# Altium schematic sheet current_cntrl.SchDoc
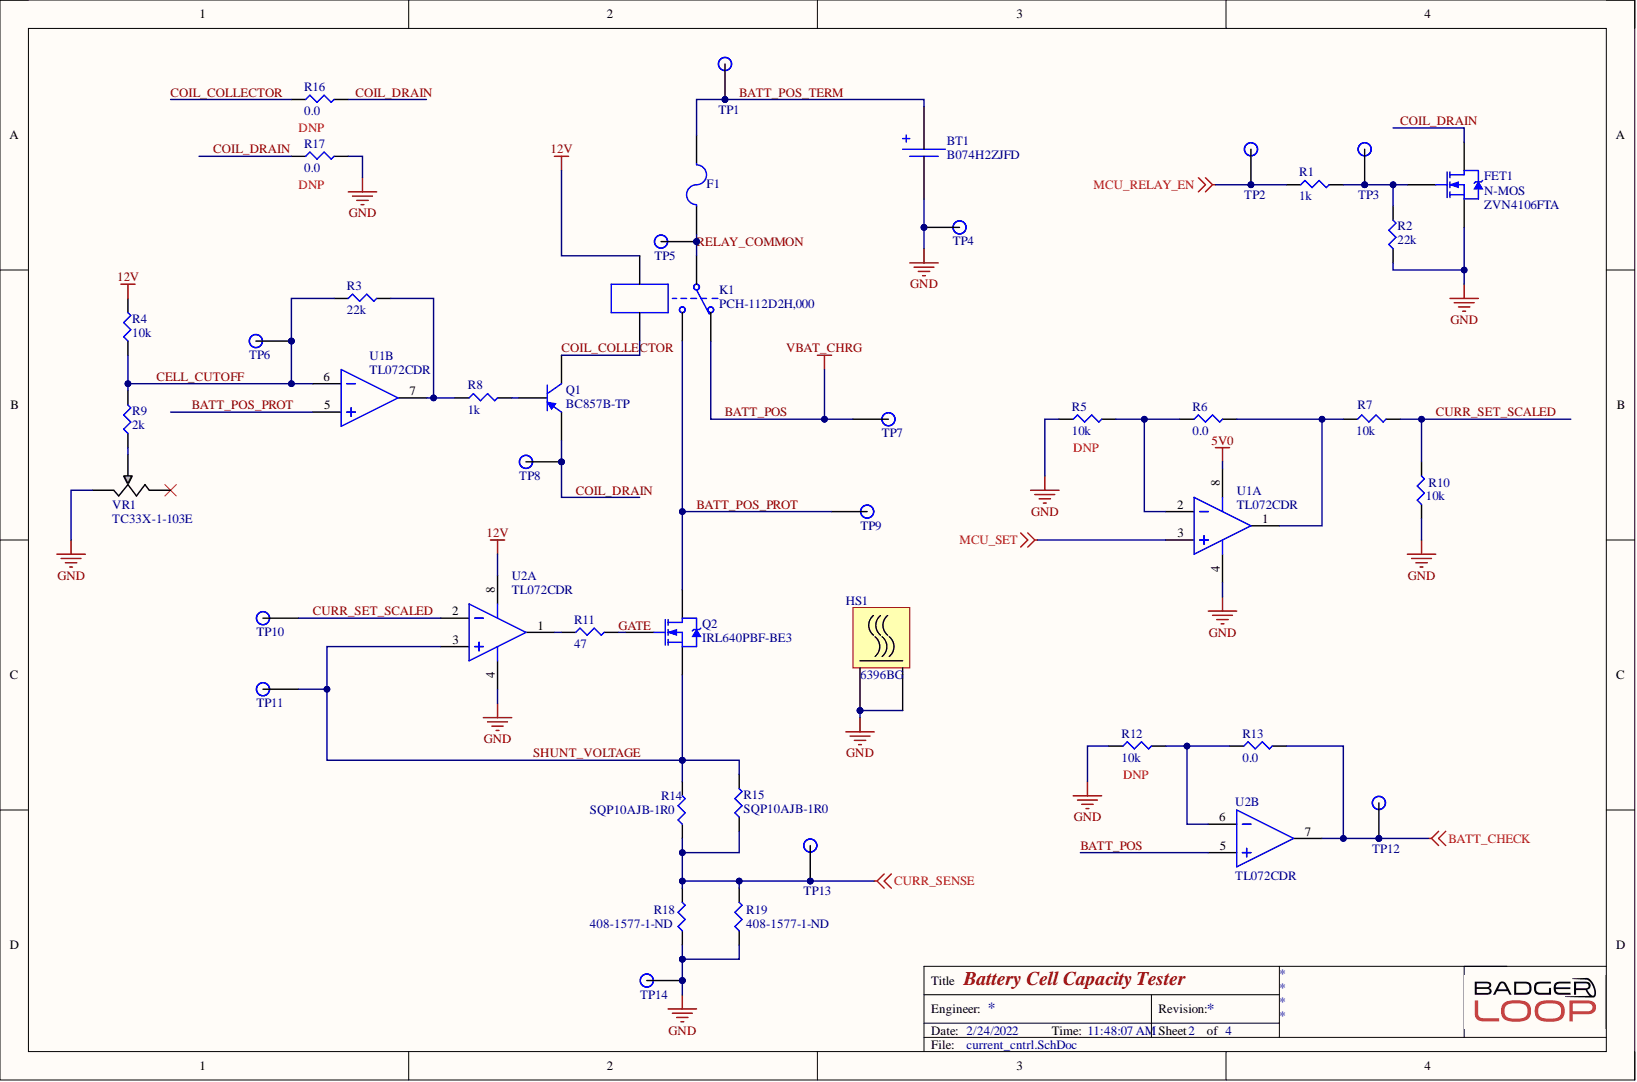
[diagram p0: current_cntrl.SchDoc]

## Parts
BT1  B074H2ZJFD  [B074H2ZJFD]
F1  3568  [Fuse Holder 30A 500V 1 Circuit Blade Through Hole]  (value not drawn)
FET1  N-MOS  Manufacturer P/N: ZVN4106FTA  [SOT95P240X110-3N]
HS1  6396BG  [6396BG]
K1  PCH-112D2H,000  [PCH-112D2H,000]
Q1  BC857B-TP  [SOT95P237X120-3N]
Q2  IRL640PBF-BE3  [TO254P470X990X1910-3P]
R1  1k  [SMD-0805-RES]
R2  22k  [SMD-0805-RES]
R3  22k  [SMD-0805-RES]
R4  10k  [SMD-0805-RES]
R5  10k  [SMD-0805-RES]
R6  0.0  [SMD-0805-RES]
R7  10k  [SMD-0805-RES]
R8  1k  [SMD-0805-RES]
R9  2k  [SMD-0805-RES]
R10  10k  [SMD-0805-RES]
R11  47  [SMD-0805-RES]
R12  10k  [SMD-0805-RES]
R13  0.0  [SMD-0805-RES]
R14  SQP10AJB-1R0  [SQP10A]
R15  SQP10AJB-1R0  [SQP10A]
R16  0.0  [SMD-0805-RES]
R17  0.0  [SMD-0805-RES]
R18  Supplier Part Number 1: 408-1577-1-ND  [SMD-1206-RES]  (hidden comment: KRL1632E-M-R039-F-T5)
R19  Supplier Part Number 1: 408-1577-1-ND  [SMD-1206-RES]  (hidden comment: KRL1632E-M-R039-F-T5)
TP1  TP  [Test Point]
TP2  TP  [Test Point]
TP3  TP  [Test Point]
TP4  TP  [Test Point]
TP5  TP  [Test Point]
TP6  TP  [Test Point]
TP7  TP  [Test Point]
TP8  TP  [Test Point]
TP9  TP  [Test Point]
TP10  TP  [Test Point]
TP11  TP  [Test Point]
TP12  TP  [Test Point]
TP13  TP  [Test Point]
TP14  TP  [Test Point]
U1  TL072CDR  [SOP127P600X175-8N]  (parts A,B)
U2  TL072CDR  [SOP127P600X175-8N]  (parts A,B)
VR1  TC33X-1-103E  [TC33X-1-103E]

## Nets
5V0: U1.8
12V: K1.1 R4.2 U2.8
BATT_CHECK: R13.2 TP12.1 U2.7
CURR_SENSE: R14.1 R15.1 R18.2 R19.2 TP13.1
GND: BT1.2 FET1.3 HS1.0 HS1.1 R2.1 R5.1 R10.1 R12.1 R17.2 R18.1 R19.1 TP4.1 TP14.1 U1.4 U2.4 VR1.1
MCU_RELAY_EN: R1.1 TP2.1
MCU_SET: U1.3
BATT_POS: K1.4 TP7.1 U2.5
BATT_POS_PROT: K1.5 Q2.2 TP9.1 U1.5
BATT_POS_TERM: BT1.1 F1.2 TP1.1
CELL_CUTOFF: R3.1 R4.1 R9.2 TP6.1 U1.6
COIL_COLLECTOR: K1.2 Q1.1 R16.1
COIL_DRAIN: FET1.2 Q1.3 R16.2 R17.1 TP8.1
CURR_SET_SCALED: R7.2 R10.2 TP10.1 U2.2
GATE: Q2.1 R11.2
RELAY_COMMON: F1.1 K1.3 TP5.1
SHUNT_VOLTAGE: Q2.3 R14.2 R15.2 TP11.1 U2.3
NetFET1_1: FET1.1 R1.2 R2.2 TP3.1
NetQ1_2: Q1.2 R8.2
NetR3_2: R3.2 R8.1 U1.7
NetR5_2: R5.2 R6.1 U1.2
NetR6_2: R6.2 R7.1 U1.1
NetR9_1: R9.1 VR1.3
NetR11_1: R11.1 U2.1
NetR12_2: R12.2 R13.1 U2.6

Not connected: VR1 2

## Library data of the parts
BT1 = B074H2ZJFD: BCP 26650 Battery Holder Case Storage Box; Number of Cells: 1; Component Kind: Standard; ComponentLink1Description: https://www.amazon.com/BCP-Plastic-Single-Battery-Storage/dp/B074H2ZJFD; ComponentLink1URL: https://www.amazon.com/BCP-Plastic-Single-Battery-Storage/dp/B074H2ZJFD; Library Name: misc.SchLib; Manufacturer: BCP; Mount: Through Hole; Pricing 1: 5=13.59(USD); Supplier 1: Amazon
F1 = 3568: FUSE HOLDER MINI AUTO PC; Manufacturer: Keystone Electronics; Approvals: UL; Body Material: Nylon; Color: Black; Current Rating: 20A; Fuse Type: Automotive; Illumination Type: Illuminated; Material: Brass,Tin,Nylon; Number of Circuits: 1; Voltage: 500V; Component Kind: Standard; ComponentLink1Description: http://www.keyelco.com/userAssets/file/M60-2p40.pdf?src-supplier=Future+Electronics; ComponentLink1URL: http://www.keyelco.com/userAssets/file/M60-2p40.pdf?src-supplier=Future+Electronics; ComponentLink2Description: https://www.alliedelec.com/m/d/15b4f09b8ba48c4b08efedd730709c21.pdf?src-supplier=Allied+Electronics; ComponentLink2URL: https://www.alliedelec.com/m/d/15b4f09b8ba48c4b08efedd730709c21.pdf?src-supplier=Allied+Electronics; Contact Material: Brass,Tin; Contact Plating: Tin; Depth: 6.73mm; Flammability Rating: UL94 V-0; Fuse Current: 20A; Lead Free: Lead Free; Length: 16mm; Library Name: misc.SchLib; Manufacturer Part Number: 3568; Max Operating Temperature: 145°C; Min Operating Temperature: -50°C; Mount: PCB,Vertical; Number of Poles: 1; Orientation: Vertical; Package Quantity: 1; REACH SVHC: No SVHC; Stock 1: 0; Supplier 1: Digi-Key; Supplier Part Number 1: 3568K-ND; Termination: PC Pin; Voltage Rating (AC): 500V
FET1 = N-MOS Enhancement Mode: MOSFET N-CH 60V 200MA SOT23-3; Manufacturer: Zetex; Component Kind: Standard; Digikey P/N: ZVN4106FCT-ND; Library Name: misc.SchLib
HS1 = 6396BG: TO-220/218 Packages 5.60 C/W Thermal Resistance Extruded Heat Sink; Manufacturer: Aavid Thermalloy; Finish: Anodized; Natural Thermal Resistance: 5.6°C/W; Shape: Fins,Rectangular; Thermal Resistance @ Forced Air Flow (100 LFM): 1.5°C/W; Case/Package: Radial; Category: Heat Sinks; Color: Black; Component Kind: Standard; ComponentLink1Description: https://datasheet.ciiva.com/15226/getdatasheetpartid-419829-15226549.pdf?src-supplier=Verical; ComponentLink1URL: https://datasheet.ciiva.com/15226/getdatasheetpartid-419829-15226549.pdf?src-supplier=Verical; ComponentLink2Description: https://datasheet.ciiva.com/1005/aavid-board-level-heatsinks-catalog-1005003.pdf?src-supplier=Digi-Key; ComponentLink2URL: https://datasheet.ciiva.com/1005/aavid-board-level-heatsinks-catalog-1005003.pdf?src-supplier=Digi-Key; Depth: 25.4mm; Height: 25.4mm; Height Off Base: 1inch; Lead Free: Lead Free; Length: 41.91mm; Library Name: misc.SchLib; Manufacturer Part Number: 6396BG; Material: Aluminium; Mount: Bolt,PC Pin,Screw; Number of Pins: 220; Package Quantity: 500; Pricing 1: 1=1.68000, 10=1.59700, 25=1.55440, 50=1.51260, 100=1.42850, 250=1.34452, 500=1.26048, 1000=1.17645, 5000=1.13443 (USD); Radiation Hardening: No; REACH SVHC: No SVHC; RoHS: Yes; RoHS Compliant: Yes; Stock 1: 13758; Supplier 1: Digi-Key; Supplier Part Number 1: 6396BG-ND; Type: Passive; Width: 41.91mm
K1 = PCH-112D2H,000: RELAY GEN PURPOSE SPDT 5A 12V; Manufacturer: TE Connectivity; Contact Form: SPDT (1 Form C); Component Kind: Standard; ComponentLink1Description: https://www.te.com/commerce/DocumentDelivery/DDEController?Action=srchrtrv&DocNm=PCH_series_relay_data_sheet_E&DocType=DS&DocLang=English; ComponentLink1URL: https://www.te.com/commerce/DocumentDelivery/DDEController?Action=srchrtrv&DocNm=PCH_series_relay_data_sheet_E&DocType=DS&DocLang=English; Digikey Link: https://www.digikey.com/en/products/detail/te-connectivity-potter-brumfield-relays/PCH-112D2H-000/1095216; Digikey P/N: PB891-ND; Library Name: misc.SchLib; Manufacturer P/N: PCH-112D2H,000
Q1 = BC857B-TP: Bipolar (BJT) Transistor PNP 45 V 100 mA 200MHz 310 mW Surface Mount SOT-23; Manufacturer: Micro Commercial Co; Component Kind: Standard; Library Name: ic.SchLib; Manufacturer Part Number: BC857B-TP; Supplier 1: Digi-Key; Supplier Part Number 1: BC857B-TPMSCT-ND
Q2 = IRL640PBF-BE3: MOSFET N-CH 200V 17A TO220AB; Manufacturer: Vishay Siliconix; Component Kind: Standard; ComponentLink1Description: https://www.digikey.com/en/products/detail/vishay-siliconix/IRL640PBF-BE3/12396452; ComponentLink1URL: https://www.digikey.com/en/products/detail/vishay-siliconix/IRL640PBF-BE3/12396452; ComponentLink2Description: https://www.mouser.com/datasheet/2/427/irl640-1768894.pdf; ComponentLink2URL: https://www.mouser.com/datasheet/2/427/irl640-1768894.pdf; ComponentLink3Description: https://www.mouser.com/ProductDetail/Vishay-Siliconix/IRL640PBF-BE3?qs=7MVldsJ5UaxejT%252BVi%2FA9OA%3D%3D; ComponentLink3URL: https://www.mouser.com/ProductDetail/Vishay-Siliconix/IRL640PBF-BE3?qs=7MVldsJ5UaxejT%252BVi%2FA9OA%3D%3D; Library Name: misc.SchLib; Supplier: Digi-Key; Supplier 1: Future Electronics; Supplier P/N: 742-IRL640PBF-BE3-ND
R1 R8 = ERJ-P06J102V: RES SMD 1K OHM 5% 1/2W 0805; Manufacturer: Panasonic Electronic Components; @ qty: 1500; Factory Stock: 0; Minimum Quantity: 1; Power (Watts): 0.5W, 1/2W; Temperature Coefficient: ±200ppm/°C; Unit Price (USD): 0.01839; Component Kind: Standard; Composition: Thick Film; Datasheets: https://industrial.panasonic.com/cdbs/www-data/pdf/RDA0000/AOA0000C243.pdf; Digi-Key Part Number: P1.0KADCT-ND; Failure Rate: -; Features: Automotive AEC-Q200, Pulse Withstanding; Height - Seated (Max): 0.028" (0.70mm); Image: //media.digikey.com/Renders/Panasonic%20Renders/ERJ-0805%20Pkg.jpg; Library Name: resistors.SchLib; Number of Terminations: 2; Operating Temperature: -55°C ~ 155°C; Package / Case: 0805 (2012 Metric); Packaging: Cut Tape (CT); Part Number: ERJ-P06J102V; Part Status: Active; Quantity Available: 124757; Series: ERJ; Size / Dimension: 0.079" L x 0.049" W (2.00mm x 1.25mm); Supplier Device Package: 0805; Tolerance: ±5%; Value: 1k
R2 R3 = ERJ-P06J223V: RES SMD 22K OHM 5% 1/2W 0805; Manufacturer: Panasonic Electronic Components; @ qty: 1500; Factory Stock: 0; Minimum Quantity: 1; Power (Watts): 0.5W, 1/2W; Temperature Coefficient: ±200ppm/°C; Unit Price (USD): 0.01839; Component Kind: Standard; Composition: Thick Film; Datasheets: https://industrial.panasonic.com/cdbs/www-data/pdf/RDA0000/AOA0000C243.pdf; Digi-Key Part Number: P22KADCT-ND; Failure Rate: -; Features: Automotive AEC-Q200, Pulse Withstanding; Height - Seated (Max): 0.028" (0.70mm); Image: //media.digikey.com/Renders/Panasonic%20Renders/ERJ-0805%20Pkg.jpg; Library Name: resistors.SchLib; Number of Terminations: 2; Operating Temperature: -55°C ~ 155°C; Package / Case: 0805 (2012 Metric); Packaging: Cut Tape (CT); Part Number: ERJ-P06J223V; Part Status: Active; Quantity Available: 10848; Series: ERJ; Size / Dimension: 0.079" L x 0.049" W (2.00mm x 1.25mm); Supplier Device Package: 0805; Tolerance: ±5%; Value: 22k
R4 = ERJ-P06J103V: RES SMD 10K OHM 5% 1/2W 0805; Manufacturer: Panasonic Electronic Components; @ qty: 1500; Factory Stock: 0; Minimum Quantity: 1; Power (Watts): 0.5W, 1/2W; Temperature Coefficient: ±200ppm/°C; Unit Price (USD): 0.01839; Component Kind: Standard; Composition: Thick Film; Datasheets: https://industrial.panasonic.com/cdbs/www-data/pdf/RDA0000/AOA0000C243.pdf; Digi-Key Part Number: P10KADCT-ND; Failure Rate: -; Features: Automotive AEC-Q200, Pulse Withstanding; Height - Seated (Max): 0.028" (0.70mm); Image: //media.digikey.com/Renders/Panasonic%20Renders/ERJ-0805%20Pkg.jpg; Library Name: resistors.SchLib; Number of Terminations: 2; Operating Temperature: -55°C ~ 155°C; Package / Case: 0805 (2012 Metric); Packaging: Cut Tape (CT); Part Number: ERJ-P06J103V; Part Status: Active; Quantity Available: 413576; Series: ERJ; Size / Dimension: 0.079" L x 0.049" W (2.00mm x 1.25mm); Supplier Device Package: 0805; Tolerance: ±5%; Value: 10k
R5 R12 = ERJ-6ENF1002V: RES SMD 10K OHM 1% 1/8W 0805; Manufacturer: Panasonic Electronic Components; @ qty: 1500; Factory Stock: 0; Minimum Quantity: 1; Power (Watts): 0.125W, 1/8W; Temperature Coefficient: ±100ppm/°C; Unit Price (USD): 0.00729; Component Kind: Standard; Composition: Thick Film; Datasheets: http://industrial.panasonic.com/www-cgi/jvcr13pz.cgi?E+PZ+3+AOA0002+ERJ6ENF1002V+7+WW; Digi-Key Part Number: P10.0KCCT-ND; DNP: DNP; Failure Rate: -; Features: Automotive AEC-Q200; Height - Seated (Max): 0.028" (0.70mm); Image: //media.digikey.com/Renders/Panasonic%20Renders/ERJ-0805%20Pkg.jpg; Library Name: resistors.SchLib; Number of Terminations: 2; Operating Temperature: -55°C ~ 155°C; Package / Case: 0805 (2012 Metric); Packaging: Cut Tape (CT); Part Number: ERJ-6ENF1002V; Part Status: Active; Quantity Available: 3985614; Series: ERJ; Size / Dimension: 0.079" L x 0.049" W (2.00mm x 1.25mm); Supplier Device Package: 0805; Tolerance: ±1%; Value: 10k
R6 R13 R16 R17 = ERJ-6GEY0R00V: RES SMD 0.0 OHM JUMPER 1/8W 0805; Manufacturer: Panasonic Electronic Components; @ qty: 1500; Factory Stock: 0; Minimum Quantity: 1; Power (Watts): 0.125W, 1/8W; Unit Price (USD): 0.00544; Component Kind: Standard; Composition: Thick Film; Datasheets: http://industrial.panasonic.com/www-cgi/jvcr13pz.cgi?E+PZ+3+AOA0001+ERJ6GEY0R00V+7+WW; Digi-Key Part Number: P0.0ACT-ND; Failure Rate: -; Features: Automotive AEC-Q200; Height - Seated (Max): 0.028" (0.70mm); Image: //media.digikey.com/Renders/Panasonic%20Renders/ERJ-0805%20Pkg.jpg; Library Name: resistors.SchLib; Number of Terminations: 2; Operating Temperature: -55°C ~ 155°C; Package / Case: 0805 (2012 Metric); Packaging: Cut Tape (CT); Part Number: ERJ-6GEY0R00V; Part Status: Active; Quantity Available: 1597627; Series: ERJ; Size / Dimension: 0.079" L x 0.049" W (2.00mm x 1.25mm); Supplier Device Package: 0805; Temperature Coefficient: -; Tolerance: Jumper; Value: 0.0
  R16 R17: DNP: DNP
R7 R10 = ERJ-6GEYJ103V: RES SMD 10K OHM 5% 1/8W 0805; Manufacturer: Panasonic Electronic Components; @ qty: 1500; Factory Stock: 0; Minimum Quantity: 1; Power (Watts): 0.125W, 1/8W; Temperature Coefficient: ±200ppm/°C; Unit Price (USD): 0.00496; Component Kind: Standard; Composition: Thick Film; Datasheets: http://industrial.panasonic.com/www-cgi/jvcr13pz.cgi?E+PZ+3+AOA0001+ERJ6GEYJ103V+7+WW; Digi-Key Part Number: P10KACT-ND; Failure Rate: -; Features: Automotive AEC-Q200; Height - Seated (Max): 0.028" (0.70mm); Image: //media.digikey.com/Renders/Panasonic%20Renders/ERJ-0805%20Pkg.jpg; Library Name: resistors.SchLib; Number of Terminations: 2; Operating Temperature: -55°C ~ 155°C; Package / Case: 0805 (2012 Metric); Packaging: Cut Tape (CT); Part Number: ERJ-6GEYJ103V; Part Status: Active; Quantity Available: 2328224; Series: ERJ; Size / Dimension: 0.079" L x 0.049" W (2.00mm x 1.25mm); Supplier Device Package: 0805; Tolerance: ±5%; Value: 10k
R9 = ERJ-P06F2001V: RES SMD 2K OHM 1% 1/2W 0805; Manufacturer: Panasonic Electronic Components; @ qty: 1500; Factory Stock: 0; Minimum Quantity: 1; Power (Watts): 0.5W, 1/2W; Temperature Coefficient: ±100ppm/°C; Unit Price (USD): 0.02534; Component Kind: Standard; Composition: Thick Film; Datasheets: https://industrial.panasonic.com/cdbs/www-data/pdf/RDA0000/AOA0000C243.pdf; Digi-Key Part Number: P16065CT-ND; Failure Rate: -; Features: Automotive AEC-Q200, Pulse Withstanding; Height - Seated (Max): 0.028" (0.70mm); Image: //media.digikey.com/Renders/Panasonic%20Renders/ERJ-0805%20Pkg.jpg; Library Name: resistors.SchLib; Number of Terminations: 2; Operating Temperature: -55°C ~ 155°C; Package / Case: 0805 (2012 Metric); Packaging: Cut Tape (CT); Part Number: ERJ-P06F2001V; Part Status: Active; Quantity Available: 19425; Series: ERJ; Size / Dimension: 0.079" L x 0.049" W (2.00mm x 1.25mm); Supplier Device Package: 0805; Tolerance: ±1%; Value: 2k
R11 = ERJ-P6WF47R0V: RES SMD 47 OHM 1% 1/2W 0805; Manufacturer: Panasonic Electronic Components; @ qty: 1500; Factory Stock: 0; Minimum Quantity: 1; Power (Watts): 0.5W, 1/2W; Temperature Coefficient: ±200ppm/°C; Unit Price (USD): 0.10507; Component Kind: Standard; Composition: Thick Film; Datasheets: https://industrial.panasonic.com/cdbs/www-data/pdf/RDA0000/AOA0000C243.pdf; Digi-Key Part Number: P16869CT-ND; Failure Rate: -; Features: Automotive AEC-Q200, Pulse Withstanding; Height - Seated (Max): 0.030" (0.75mm); Image: //media.digikey.com/Renders/Panasonic%20Renders/ERJ-0805%20Pkg.jpg; Library Name: resistors.SchLib; Number of Terminations: 2; Operating Temperature: -55°C ~ 155°C; Package / Case: 0805 (2012 Metric); Packaging: Cut Tape (CT); Part Number: ERJ-P6WF47R0V; Part Status: Active; Quantity Available: 3398; Series: ERJ; Size / Dimension: 0.079" L x 0.049" W (2.00mm x 1.25mm); Supplier Device Package: 0805; Tolerance: ±1%; Value: 47
R14 R15 = SQP10AJB-1R0: RES 1 OHM 5% 10W AXIAL; Manufacturer: YAGEO; Component Kind: Standard; ComponentLink1Description: https://www.yageo.com/upload/media/product/productsearch/datasheet/lr/YAGEO%20SQP_NSP_datasheet_2021v0.pdf; ComponentLink1URL: https://www.yageo.com/upload/media/product/productsearch/datasheet/lr/YAGEO%20SQP_NSP_datasheet_2021v0.pdf; Digikey Link: https://www.digikey.com/en/products/detail/yageo/SQP10AJB-1R0/18711; Library Name: resistors.SchLib; Manufacturer Part Number: SQP10AJB-1R0; Pricing 1: 1=0.81,10=7.00 (USD); Supplier 1: Digi-Key; Supplier Part Number 1: 1.0W-10-ND
R18 R19 = KRL1632E-M-R039-F-T5: 39 mOhms ±1% 0.75W, 3/4W Chip Resistor 1206 (3216 Metric) Automotive AEC-Q200, Current Sense Metal Foil; Resistance: 0.039; Component Kind: Standard; ComponentLink1Description: https://www.digikey.com/en/products/detail/susumu/KRL1632E-M-R039-F-T5/3738003; ComponentLink1URL: https://www.digikey.com/en/products/detail/susumu/KRL1632E-M-R039-F-T5/3738003; Library Name: resistors.SchLib; Manufacturer Part Number: KRL1632E-M-R039-F-T5; Supplier 1: Digi-Key
TP1-TP14 = TP: SMD TEST POINT; Component Kind: Standard; Library Name: misc.SchLib
U1 U2 = TL072CDR: IC OPAMP JFET 3MHZ 8SOIC; Manufacturer: Texas Instruments; Common Mode Rejection Ratio: 70dB; Dual Supply Voltage: 9V; Input Bias Current: 200pA; Input Offset Voltage (Vos): 10mV; Max Dual Supply Voltage: 18V; Min Dual Supply Voltage: 3.5V; Nominal Supply Current: 5mA; Number of Amplifiers: 2; Operating Supply Current: 1.4mA; Slew Rate: 13V/µs; Voltage Gain: 106.02dB; Bandwidth: 3MHz; Case/Package: D; Component Kind: Standard; ComponentLink1Description: http://www.ti.com/lit/ds/symlink/tl071a.pdf?src-supplier=Digi-Key; ComponentLink1URL: http://www.ti.com/lit/ds/symlink/tl071a.pdf?src-supplier=Digi-Key; ComponentLink2Description: http://www.ti.com/general/docs/lit/getliterature.tsp?genericPartNumber=TL072&&fileType=pdf&src-supplier=Avnet; ComponentLink2URL: http://www.ti.com/general/docs/lit/getliterature.tsp?genericPartNumber=TL072&&fileType=pdf&src-supplier=Avnet; ComponentLink3Description: http://www.farnell.com/datasheets/1882732.pdf?src-supplier=Element14; ComponentLink3URL: http://www.farnell.com/datasheets/1882732.pdf?src-supplier=Element14; Contact Plating: Gold; Gain Bandwidth Product: 3MHz; Height: 1.58mm; Lead Free: Lead Free; Length: 4.9mm; Library Name: ic.SchLib; Max I/O Voltage: 10mV; Max Operating Temperature: 85°C; Max Supply Voltage: 18V; Min Operating Temperature: -40°C; Nominal Gain Bandwidth Product: 3MHz; Number of Channels: 2; Number of Circuits: 2; Number of Elements: 2; Number of Pins: 8; Package Quantity: 1; Packaging: Tape and Reel; Pricing 1: 1=0.41, 10=0.334, 100=0.2059, 500=0.1562, 1000=0.11715 (USD); Radiation Hardening: No; REACH SVHC: No SVHC; Stock 1: 21821; Supplier 1: Digi-Key; Supplier Part Number 1: 296-1282-6-ND; Unity Gain Bandwidth Product: 3MHz; Width: 3.91mm
VR1 = TC33X-1-103E: TRIMMER 10K OHM 0.1W J LEAD TOP; Manufacturer: Bourns Inc.; Component Kind: Standard; Library Name: misc.SchLib; Manufacturer Part Number: TC33X-1-103E; Supplier 1: Digi-Key; Supplier Part Number 1: TC33X-1-103ECT-ND
